# Altium schematic sheet P09-USB_JTAG.SchDoc
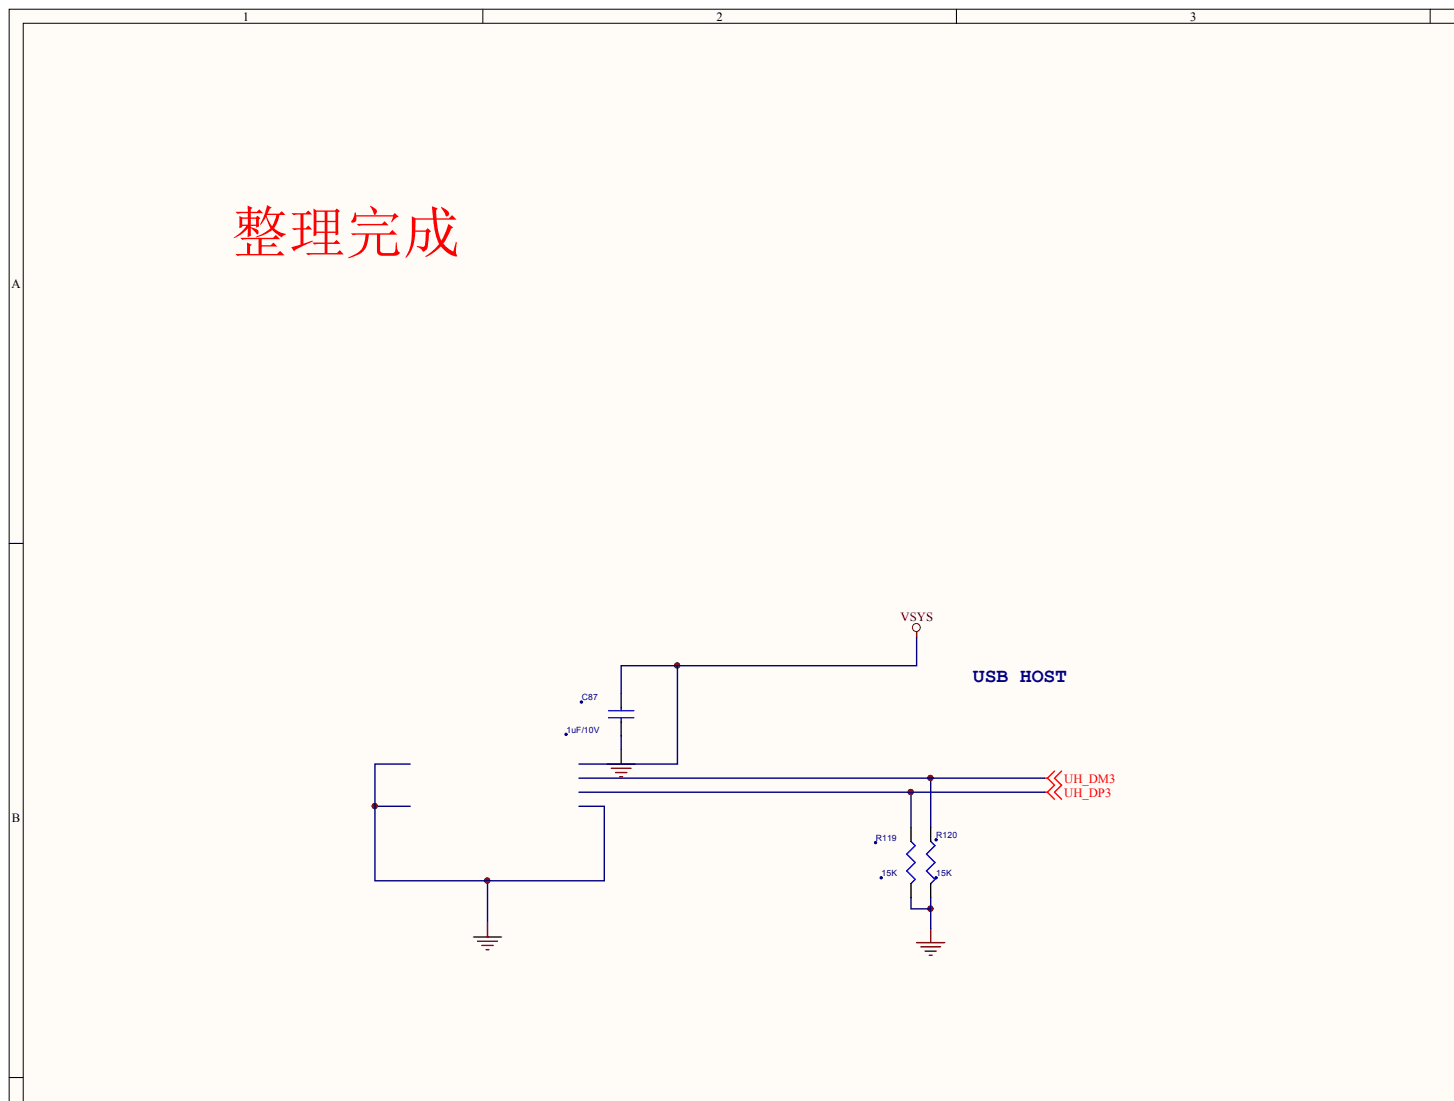
[diagram p0: P09-USB_JTAG.SchDoc, top left]
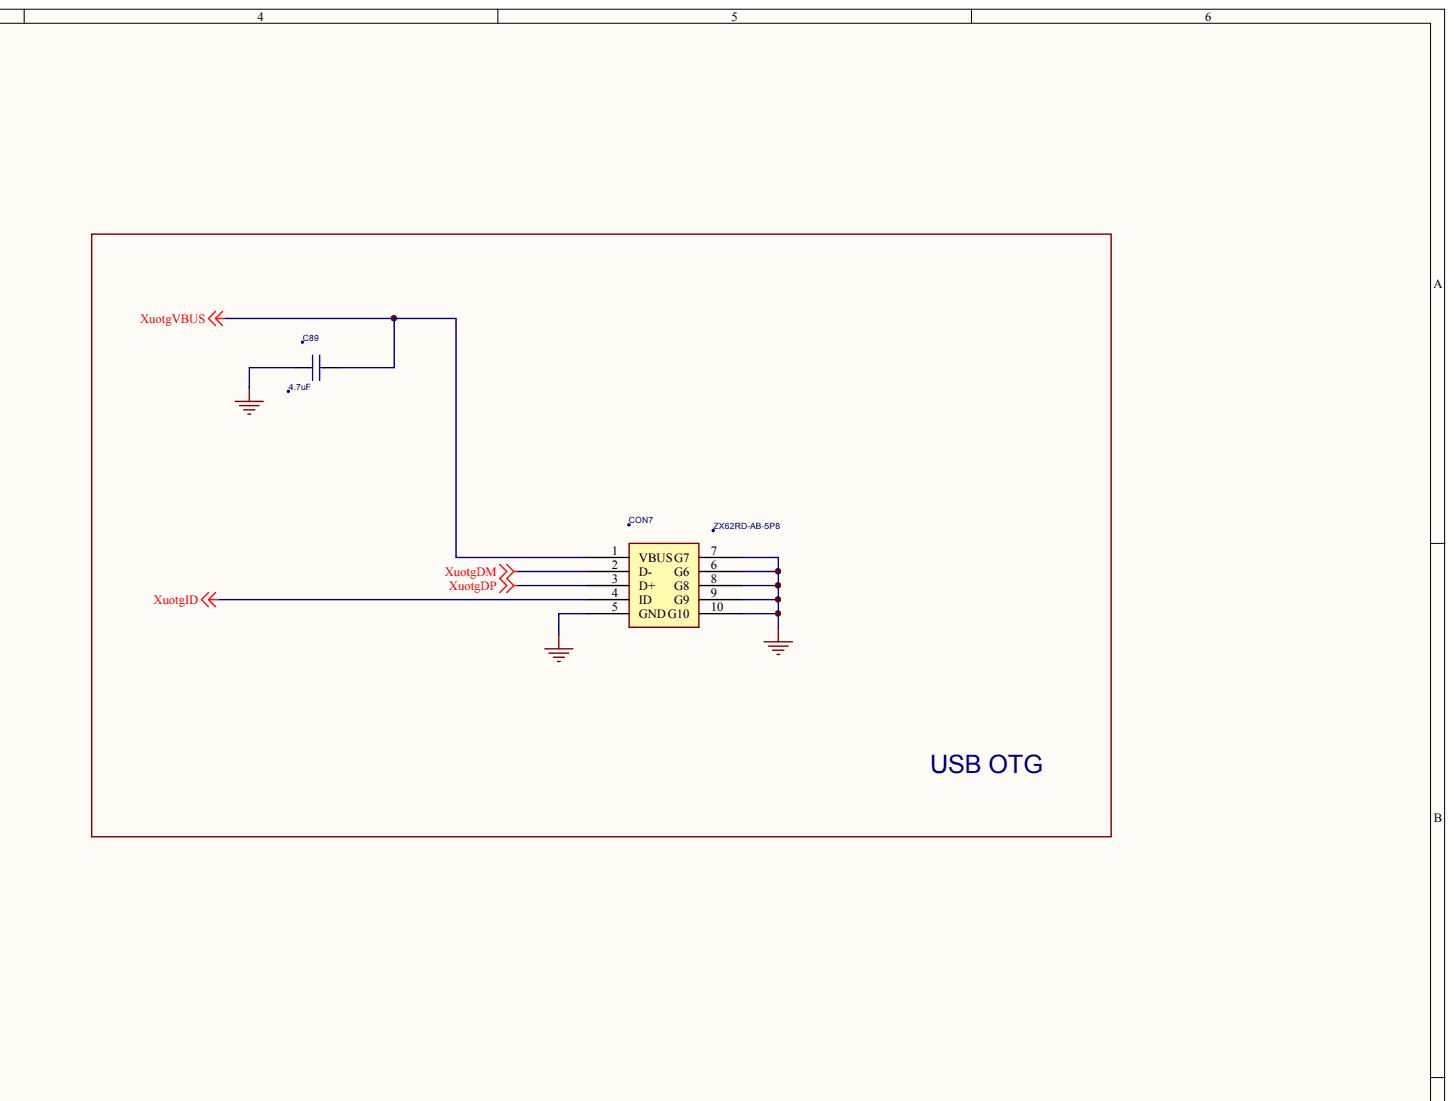
[diagram p1: P09-USB_JTAG.SchDoc, top right]
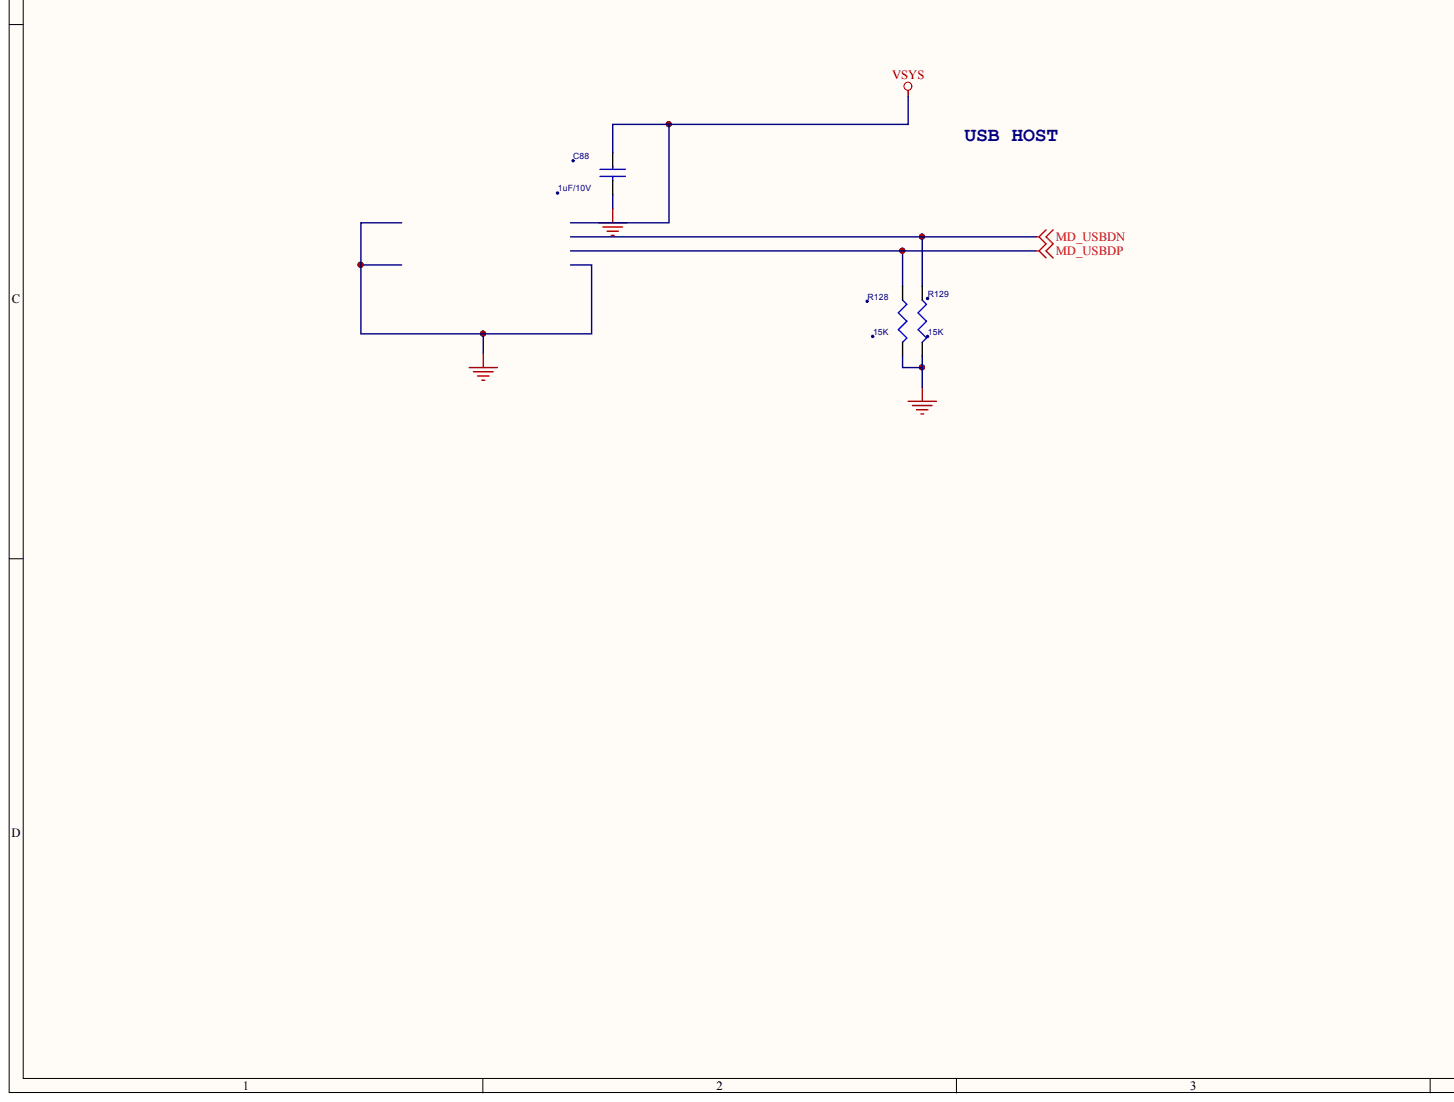
[diagram p2: P09-USB_JTAG.SchDoc, bottom left]
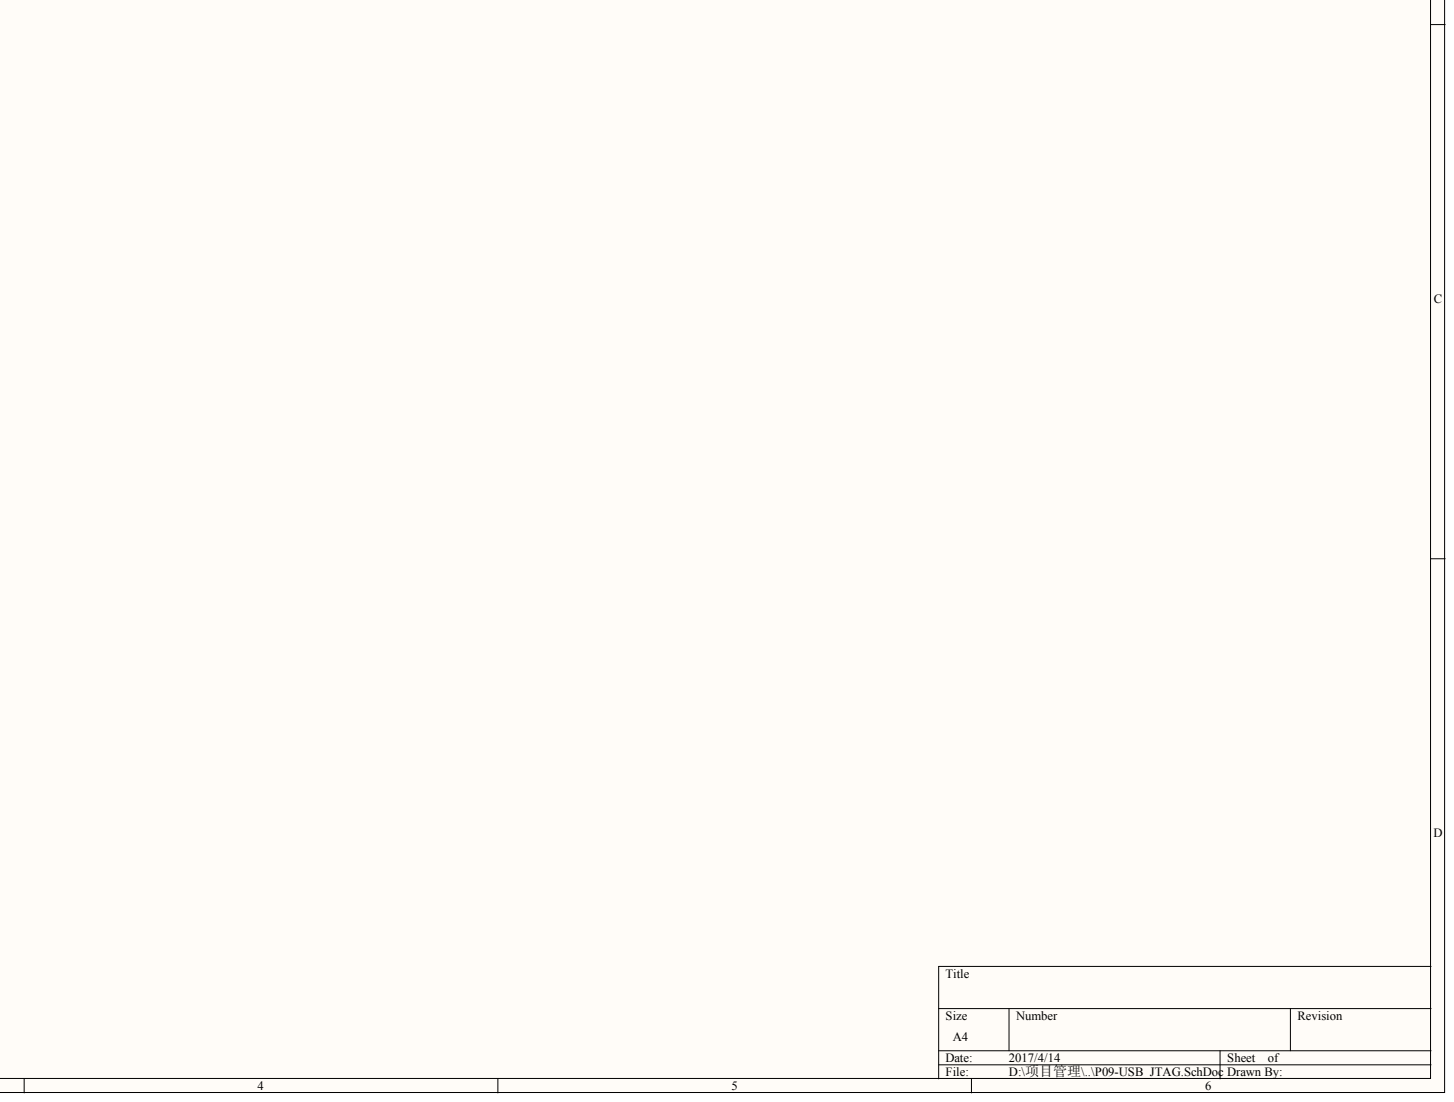
[diagram p3: P09-USB_JTAG.SchDoc, bottom right]

## Parts
C87  1uF/10V
C88  1uF/10V
C89  4.7uF
CON7  ZX62RD-AB-5P8
R119  15K
R120  15K
R128  15K
R129  15K

## Nets
GND: C87.2 C88.2 C89.1 CON7.5(GND) CON7.6(G6) CON7.7(G7) CON7.8(G8) CON7.9(G9) CON7.10(G10) R119.1 R120.1 R128.1 R129.1
MD_USBDN: R129.2
MD_USBDP: R128.2
UH_DM3: R120.2
UH_DP3: R119.2
VSYS: C87.1 C88.1
XUOTGDM: CON7.2(D-)
XUOTGDP: CON7.3(D+)
XUOTGID: CON7.4(ID)
XUOTGVBUS: C89.2 CON7.1(VBUS)
